# Altium schematic sheet PSLV_Interface.SchDoc
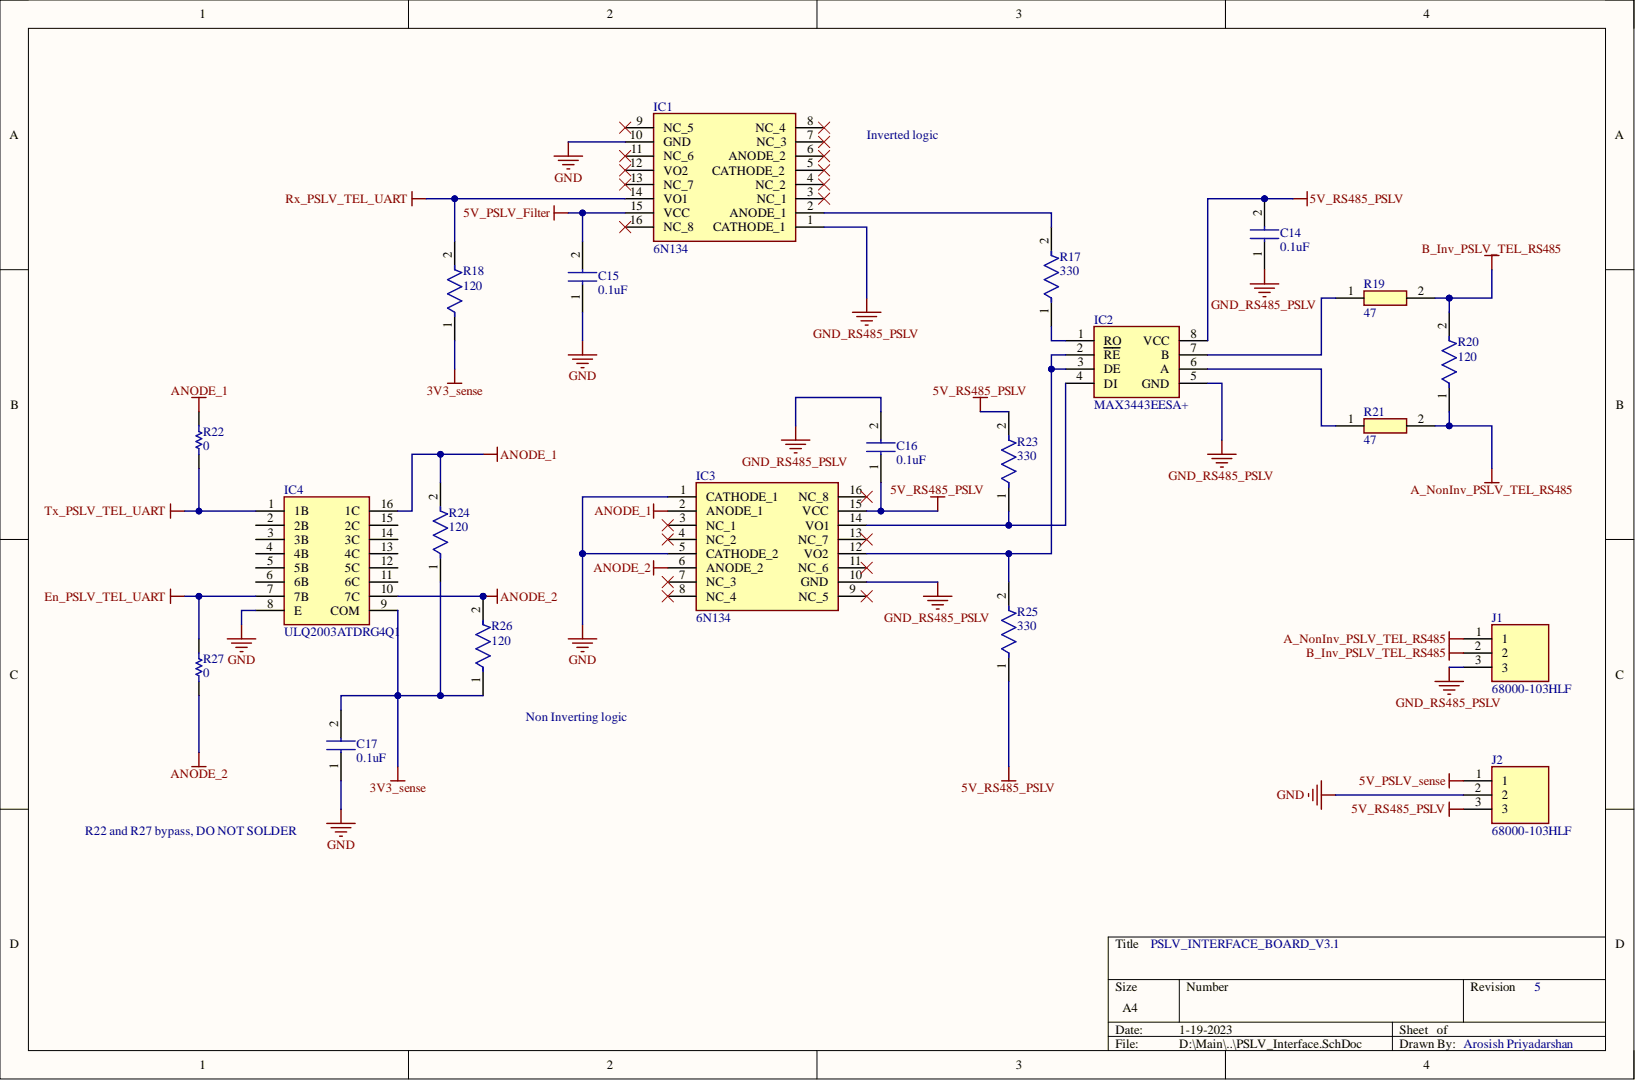
[diagram p0: PSLV_Interface.SchDoc]

## Parts
C14  0.1uF  [C1206_FT]
C15  0.1uF  [C1206_FT]
C16  0.1uF  [C1206_FT]
C17  0.1uF  [C1206_FT]
IC1  6N134  [DIP762W51P254L2044H445Q16N]
IC2  MAX3443EESA+  [SOIC127P600X175-8N]
IC3  6N134  [DIP762W51P254L2044H445Q16N]
IC4  ULQ2003ATDRG4Q1  [SOIC127P600X175-16N]
J1  68000-103HLF  [HDRV3W67P0X254_1X3_762X241X839P]
J2  68000-103HLF  [HDRV3W67P0X254_1X3_762X241X839P]
R17  330  [FP-CRCW1210-e3-IPC_B]
R18  120  [FP-RN732B-IPC_C]
R19  47  [RESC3216X55N]
R20  120  [FP-RN732B-IPC_C]
R21  47  [RESC3216X55N]
R22  0  [RESC3216X70X50LL05T20]
R23  330  [FP-CRCW1210-e3-IPC_B]
R24  120  [FP-RN732B-IPC_C]
R25  330  [FP-CRCW1210-e3-IPC_B]
R26  120  [FP-RN732B-IPC_C]
R27  0  [RESC3216X70X50LL05T20]

## Nets
3V3_sense: C17.2 IC4.9(COM) R18.1 R24.1 R26.1
5V_PSLV_Filter: C15.2 IC1.15(VCC)
5V_PSLV_sense: J2.1
5V_RS485_PSLV: C14.2 C16.1 IC2.8(VCC) IC3.15(VCC) J2.3 R23.2 R25.1
A_NonInv_PSLV_TEL_RS485: J1.1 R20.1 R21.2
ANODE_1: IC3.2(ANODE_1) IC4.16(1C) R22.2 R24.2
ANODE_2: IC3.6(ANODE_2) IC4.10(7C) R26.2 R27.2
B_Inv_PSLV_TEL_RS485: J1.2 R19.2 R20.2
En_PSLV_TEL_UART: IC4.7(7B) R27.1
GND: C15.1 C17.1 IC1.10(GND) IC3.1(CATHODE_1) IC3.5(CATHODE_2) IC4.8(E) J2.2
GND_RS485_PSLV: C14.1 C16.2 IC1.1(CATHODE_1) IC2.5(GND) IC3.10(GND) J1.3
Rx_PSLV_TEL_UART: IC1.14(VO1) R18.2
Tx_PSLV_TEL_UART: IC4.1(1B) R22.1
NetIC1_2: IC1.2(ANODE_1) R17.2
NetIC2_1: IC2.1(RO) R17.1
NetIC2_2: IC2.2(RE) IC2.3(DE) IC3.12(VO2) R25.2
NetIC2_4: IC2.4(DI) IC3.14(VO1) R23.1
NetIC2_6: IC2.6(A) R21.1
NetIC2_7: IC2.7(B) R19.1

Not connected: IC1 3(NC_1) 4(NC_2) 5(CATHODE_2) 6(ANODE_2) 7(NC_3) 8(NC_4) 9(NC_5) 11(NC_6) 12(VO2) 13(NC_7) 16(NC_8); IC3 3(NC_1) 4(NC_2) 7(NC_3) 8(NC_4) 9(NC_5) 11(NC_6) 13(NC_7) 16(NC_8); IC4 2(2B) 3(3B) 4(4B) 5(5B) 6(6B) 11(6C) 12(5C) 13(4C) 14(3C) 15(2C)

## Library data of the parts
C14-C17 = C1206X104K5RAC3316: Capacitor; Manufacturer_Name: KEMET; Arrow Part Number: C1206X104K5RAC3316; Arrow Price/Stock: https://www.arrow.com/en/products/c1206x104k5rac3316/kemet-corporation; Component Kind: Standard; Datasheet Link: https://content.kemet.com/datasheets/KEM_X7R_FT_VW_AUDI.pdf; Library Name: Schlib1.SchLib; Manufacturer_Part_Number: C1206X104K5RAC3316; Mouser Part Number: 80-C1206X104K5RC3316; Mouser Price/Stock: https://www.mouser.co.uk/ProductDetail/KEMET/C1206X104K5RAC3316?qs=PzGy0jfpSMtwBv5U2rNx3w%3D%3D
IC1 IC3 = 6N134: Integrated Circuit; Manufacturer_Name: Avago Technologies; Component Kind: Standard; Datasheet Link: https://docs.broadcom.com/docs/AV02-1336EN; Height: 4.45mm; Manufacturer_Part_Number: 6N134; Mouser Part Number: 630-6N134; Mouser Price/Stock: https://www.mouser.co.uk/ProductDetail/Broadcom-Avago/6N134?qs=RuhU64sK2%252BsmvK6DjUrWYQ%3D%3D
IC2 = MAX3443EESA+: Integrated Circuit; Manufacturer_Name: Maxim Integrated; Component Kind: Standard; Datasheet Link: https://datasheet.datasheetarchive.com/originals/distributors/Datasheets-DGA13/1189461.pdf; Height: 1.75mm; Manufacturer_Part_Number: MAX3443EESA+; Mouser Part Number: 700-MAX3443EESA; Mouser Price/Stock: https://www.mouser.com/Search/Refine.aspx?Keyword=700-MAX3443EESA
IC4 = ULQ2003ATDRG4Q1: Integrated Circuit; Manufacturer_Name: Texas Instruments; Arrow Part Number: ULQ2003ATDRG4Q1; Arrow Price/Stock: https://www.arrow.com/en/products/ulq2003atdrg4q1/texas-instruments?region=nac; Component Kind: Standard; Datasheet Link: https://www.ti.com/lit/ds/symlink/ulq2003a-q1.pdf?ts=1619090227094&ref_url=https%253A%252F%252Fwww.ti.com%252Fstore%252Fti%252Fen%252Fp%252Fproduct%252F%253Fp%253DULQ2003ATDRG4Q1; Height: 1.75mm; Manufacturer_Part_Number: ULQ2003ATDRG4Q1; Mouser Part Number: 595-ULQ2003ATDRG4Q1; Mouser Price/Stock: https://www.mouser.co.uk/ProductDetail/Texas-Instruments/ULQ2003ATDRG4Q1?qs=ql1K%252BkDau3qyd2TN3KWBjQ%3D%3D
J1 J2 = 68000-103HLF: Connector; Manufacturer_Name: Amphenol Communication Solutions; Arrow Part Number: 68000-103HLF; Arrow Price/Stock: https://www.arrow.com/en/products/68000-103hlf/amphenol-fci?region=europe; Component Kind: Standard; Datasheet Link: https://cdn.amphenol-cs.com/media/wysiwyg/files/drawing/68000.pdf; Height: 8.39mm; Manufacturer_Part_Number: 68000-103HLF; Mouser Part Number: 649-68000-103HLF; Mouser Price/Stock: https://www.mouser.co.uk/ProductDetail/Amphenol-FCI/68000-103HLF?qs=zh4O8xspOuyqPBhcuxtliw%3D%3D
R17 R23 R25 = CMP-2005-00031-2: RES SMD 205K OHM 1% 1/2W 1947; Max Power Dissipation: 500mW; Resistance: 330R; Temperature Coefficient: 100ppm/°C; Voltage Rating: 200V; Case Code (Imperial): 1210; Case Code (Metric): 3225; Case/Package: 1210; Component Kind: Standard; Composition: Thick Film; Contact Plating: Tin; Depth: 2.5mm; Features: Automotive AEC-Q200; Height: 0.024inch; Lead Free: Lead Free; Length: 3.2mm; Library Name: Altium Content Vault; Max Operating Temperature: 155°C; Military Standard: Not; Min Operating Temperature: -55°C; Number of Terminations: 2; Package Quantity: 1; Packaging: Tape and Reel; Power Rating: 500mW; Radiation Hardening: No; Termination: SMD/SMT; Tolerance: 1%; Voltage Rating (DC): 200V; Weight: 0.000564oz; Width: 0.098inch
R18 R20 R24 R26 = CMP-04015-008537-1: 120Ω ±1% 0.125W, 1/8W Chip Resistor 1206 (3216 Metric) Moisture Resistant Thin Film; Component Kind: Standard; Library Name: Altium Content Vault
R19 R21 = HRG3216P-47R0-B-T1: Resistor; Manufacturer_Name: Susumu; Component Kind: Standard; Datasheet Link: http://www.susumu.co.jp/common/pdf/n_catalog_partition24_en.pdf; Height: 0.55mm; Manufacturer_Part_Number: HRG3216P-47R0-B-T1; Mouser Part Number: 754-HRG3216P47R0BT1; Mouser Price/Stock: https://www.mouser.co.uk/ProductDetail/Susumu/HRG3216P-47R0-B-T1?qs=Pccw3SRxl2SAb%2FGul9RnXA%3D%3D
R22 R27 = CMP-2003-00541-1: Manufacturer: Panasonic; Part Number: ERJ-8GEY0R00V; Component Kind: Standard; Library Name: Altium Content Vault
